# Altium schematic sheet Memory.SchDoc
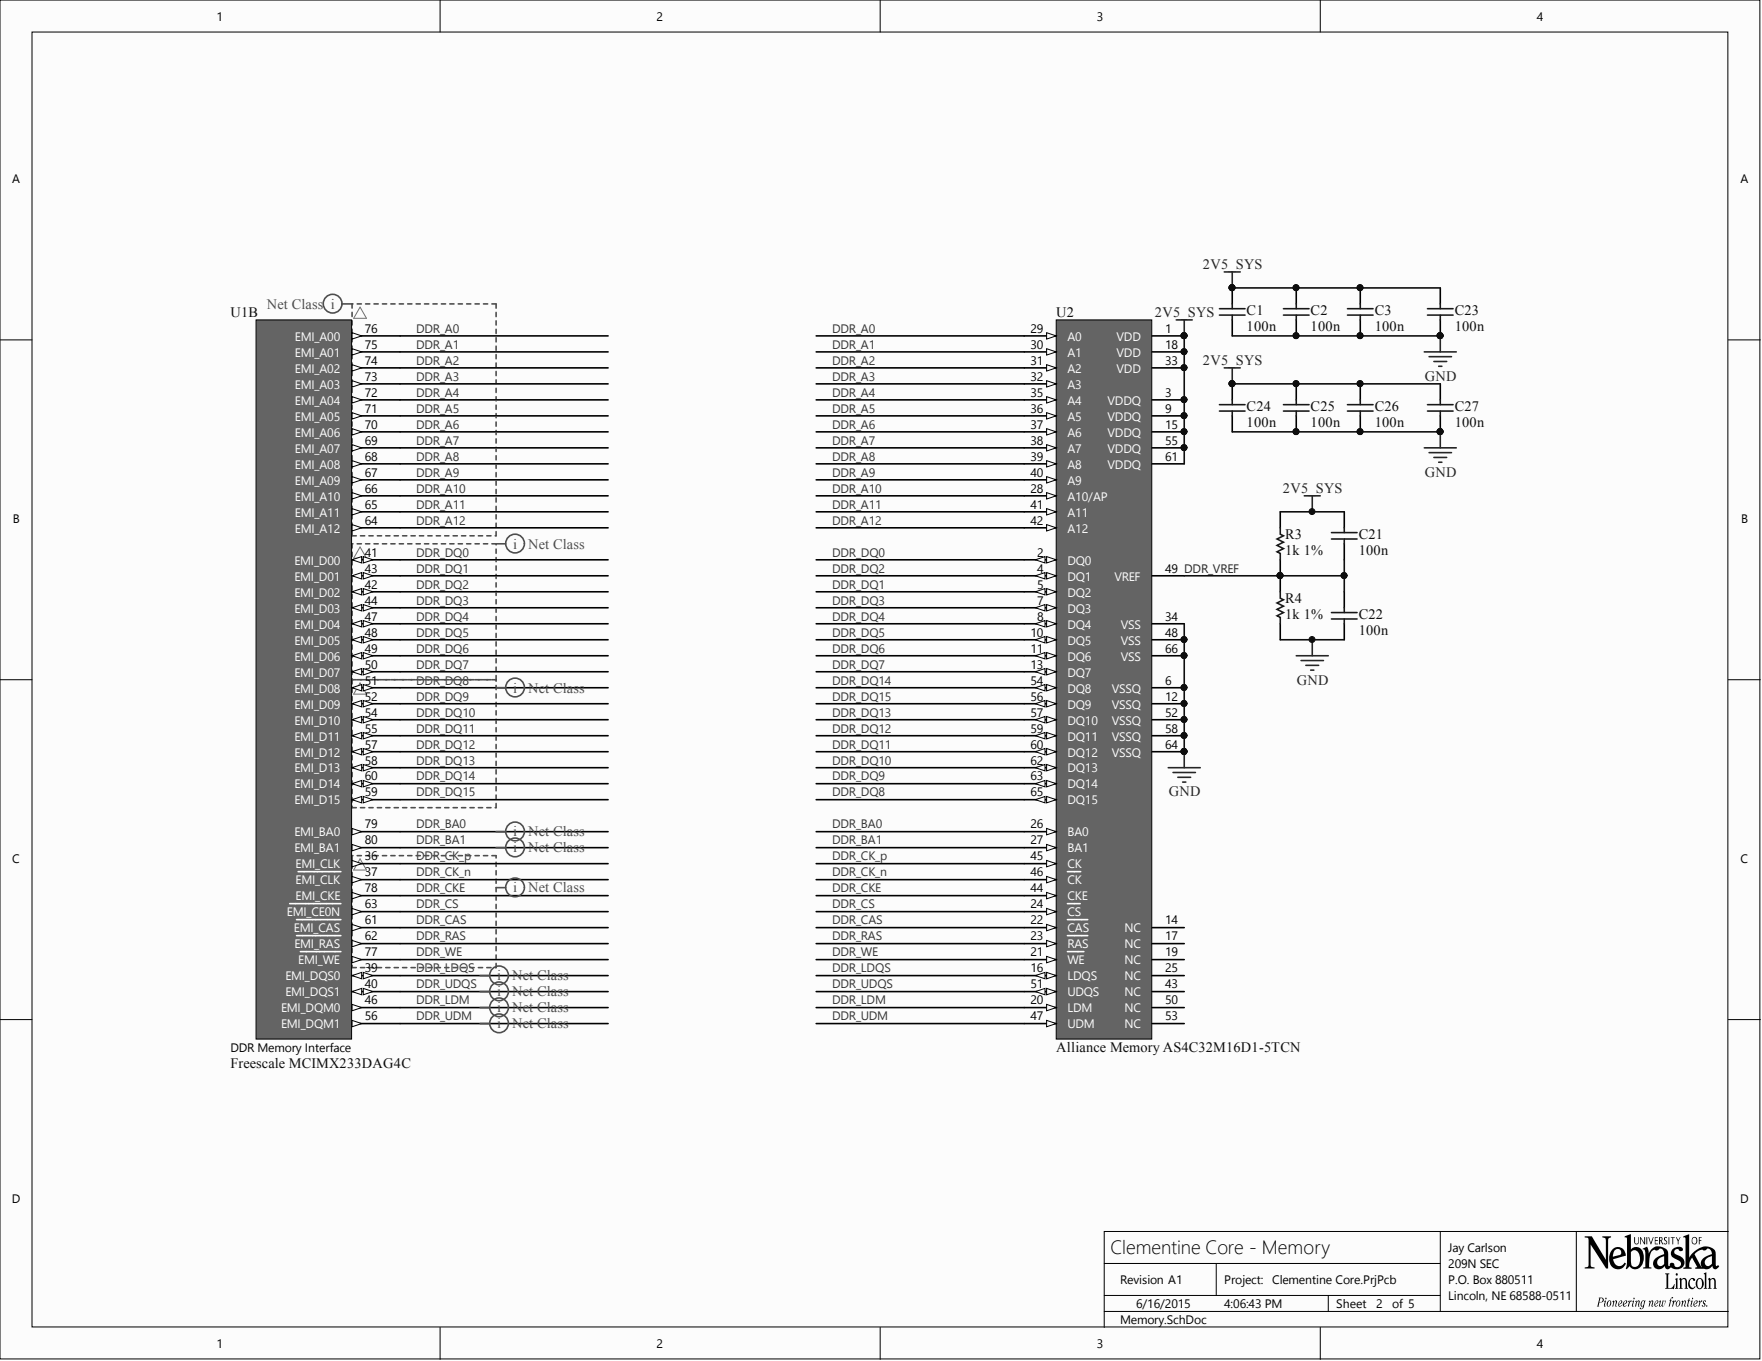
[diagram p0: Memory.SchDoc]

## Parts
C1  100n  [CAPC1005X55X25LL05T10]
C2  100n  [CAPC1005X55X25LL05T10]
C3  100n  [CAPC1005X55X25LL05T10]
C21  100n  [CAPC1005X55X25LL05T10]
C22  100n  [CAPC1005X55X25LL05T10]
C23  100n  [CAPC1005X55X25LL05T10]
C24  100n  [CAPC1005X55X25LL05T10]
C25  100n  [CAPC1005X55X25LL05T10]
C26  100n  [CAPC1005X55X25LL05T10]
C27  100n  [CAPC1005X55X25LL05T10]
R3  1k 1%  [RESC1005X40X25NL05T05]
R4  1k 1%  [RESC1005X40X25NL05T05]
U1  Freescale MCIMX233DAG4C  Manufacturer Part Number: MCIMX233DAG4C  [PCB-1234233-1]  (part B)
U2  Alliance Memory AS4C32M16D1-5TCN  Family: Memory  Manufacturer Part Number: AS4C32M16D1-5TCN  [PCB-7635244-1]

## Nets
2V5_SYS: C1.2 C2.2 C3.2 C21.2 C23.2 C24.2 C25.2 C26.2 C27.2 R3.2 U2.1(VDD) U2.3(VDDQ) U2.9(VDDQ) U2.15(VDDQ) U2.18(VDD) U2.33(VDD) U2.55(VDDQ) U2.61(VDDQ)
GND: C1.1 C2.1 C3.1 C22.1 C23.1 C24.1 C25.1 C26.1 C27.1 R4.1 U2.6(VSSQ) U2.12(VSSQ) U2.34(VSS) U2.48(VSS) U2.52(VSSQ) U2.58(VSSQ) U2.64(VSSQ) U2.66(VSS)
DDR_A0: U1.76(EMI_A00) U2.29(A0)
DDR_A1: U1.75(EMI_A01) U2.30(A1)
DDR_A2: U1.74(EMI_A02) U2.31(A2)
DDR_A3: U1.73(EMI_A03) U2.32(A3)
DDR_A4: U1.72(EMI_A04) U2.35(A4)
DDR_A5: U1.71(EMI_A05) U2.36(A5)
DDR_A6: U1.70(EMI_A06) U2.37(A6)
DDR_A7: U1.69(EMI_A07) U2.38(A7)
DDR_A8: U1.68(EMI_A08) U2.39(A8)
DDR_A9: U1.67(EMI_A09) U2.40(A9)
DDR_A10: U1.66(EMI_A10) U2.28(A10/AP)
DDR_A11: U1.65(EMI_A11) U2.41(A11)
DDR_A12: U1.64(EMI_A12) U2.42(A12)
DDR_BA0: U1.79(EMI_BA0) U2.26(BA0)
DDR_BA1: U1.80(EMI_BA1) U2.27(BA1)
DDR_CAS: U1.61(EMI_CAS) U2.22(CAS)
DDR_CK_N: U1.37(EMI_CLK) U2.46(CK)
DDR_CK_P: U1.36(EMI_CLK) U2.45(CK)
DDR_CKE: U1.78(EMI_CKE) U2.44(CKE)
DDR_CS: U1.63(EMI_CE0N) U2.24(CS)
DDR_DQ0: U1.41(EMI_D00) U2.2(DQ0)
DDR_DQ1: U1.43(EMI_D01) U2.5(DQ2)
DDR_DQ2: U1.42(EMI_D02) U2.4(DQ1)
DDR_DQ3: U1.44(EMI_D03) U2.7(DQ3)
DDR_DQ4: U1.47(EMI_D04) U2.8(DQ4)
DDR_DQ5: U1.48(EMI_D05) U2.10(DQ5)
DDR_DQ6: U1.49(EMI_D06) U2.11(DQ6)
DDR_DQ7: U1.50(EMI_D07) U2.13(DQ7)
DDR_DQ8: U1.51(EMI_D08) U2.65(DQ15)
DDR_DQ9: U1.52(EMI_D09) U2.63(DQ14)
DDR_DQ10: U1.54(EMI_D10) U2.62(DQ13)
DDR_DQ11: U1.55(EMI_D11) U2.60(DQ12)
DDR_DQ12: U1.57(EMI_D12) U2.59(DQ11)
DDR_DQ13: U1.58(EMI_D13) U2.57(DQ10)
DDR_DQ14: U1.60(EMI_D14) U2.54(DQ8)
DDR_DQ15: U1.59(EMI_D15) U2.56(DQ9)
DDR_LDM: U1.46(EMI_DQM0) U2.20(LDM)
DDR_LDQS: U1.39(EMI_DQS0) U2.16(LDQS)
DDR_RAS: U1.62(EMI_RAS) U2.23(RAS)
DDR_UDM: U1.56(EMI_DQM1) U2.47(UDM)
DDR_UDQS: U1.40(EMI_DQS1) U2.51(UDQS)
DDR_VREF: C21.1 C22.2 R3.1 R4.2 U2.49(VREF)
DDR_WE: U1.77(EMI_WE) U2.21(WE)
N00046:
N00047:
N00048:

Not connected: U2 14(NC) 17(NC) 19(NC) 25(NC) 43(NC) 50(NC) 53(NC)

## Library data of the parts
C1-C3 C21-C27 = CMP-19299678-1: CAP CER 0.1UF 16V Y5V 0402; Manufacturer: Murata; Manufacturer Part Number: GRM155F51C104ZA01D; Brand: Murata Electronics; Capacitance - nF: 100 nF; Capacitance - pF: 100000 pF; Case Height: 0.5 mm; Case Length: 1 mm; Temperature Coefficient / Code: - 82 %, + 22 %; Tolerance: - 20 %, + 80 %; Type: General Purpose MLCC; Capacitance: 0.1 uF; Case Code - in: 0402; Case Code - mm: 1005; Case Width: 0.5 mm; CiivaID: 19299678; Ciiva URL: https://ciiva.com/part/grm155f51c104za01d-19299678; Component Kind: Standard; Dielectric: Y5V; Dimensions: 0.5 mm W x 1 mm L x 0.5 mm H; Factory Pack Quantity: 10000; Library Name: CommunityVault; Maximum Operating Temperature: + 85 C; Minimum Operating Temperature: - 30 C; Operating Temperature Range: - 30 C to + 85 C; Package / Case: 0402 (1005 metric); Packaging: Reel; Product: General Type MLCCs; Product Category: Multilayer Ceramic Capacitors MLCC - SMD/SMT; RoHS: Details; Series: GRM; Termination Style: SMD/SMT; Voltage Rating: 16 V; Voltage Rating DC: 16 V
R3 R4 = CMP-1846973-1: Res Thick Film 0402 1K Ohm 1% 0.1W(1/10W) ±100ppm/C Molded SMD Automotive Punched T/R; Manufacturer Part Number: ERJ-2RKF1001X; Resistance: 1 kO; Temp Coefficient: ±100 ppm/°C; CiivaID: 1846973; Ciiva URL: https://ciiva.com/part/erj-2rkf1001x-1846973; Component Kind: Standard; Library Name: CommunityVault; Rated Power: 0.1 W; Size Code: 0402; Tolerance (%): ±1 %
U1 = CMP-1234233-6: MPU 32BIT I.MX233 128LQFP; Processing Unit: Microprocessor; Rad Hardened: No; Screening Level: Commercial; CiivaID: 1234233; Ciiva URL: https://ciiva.com/part/mcimx233dag4c-1234233; Component Kind: Standard; Library Name: CommunityVault; Mounting: Surface Mount; Package Type: LQFP; Packaging: Tray; Pin Count: 128; Technology: 0.09um
U2 = CMP-7635244-1: DRAM 512Mb, 3.3V, 200Mhz 32M x 16 DDR; Dynamic Catalog: DDR SDRAM; Format - Memory: RAM; Interface: Parallel; Memory Size: 512M (32M x 16); Other Names: 1450-1006; Voltage - Supply: 2.3 V ~ 2.7 V; Category: Integrated Circuits (ICs); CiivaID: 7635244; Ciiva URL: https://ciiva.com/part/as4c32m16d1-5tcn-7635244; Component Kind: Standard; Library Name: CommunityVault; Memory Type: DDR SDRAM; Minimum Quantity: RAM; Online Catalog: DDR SDRAM; Operating Temperature: 0°C ~ 70°C; Package / Case: 66-TSSOP (0.400", 10.16mm Width); Package/Case: 66-TSSOP (0.400", 10.16mm Width); Packaging: Tray; Quantity Available: Tray; Speed: 200MHz; Standard Package: 108; Supplier Device Package: 66-TSOP II
